# Altium schematic sheet SSS Daughter Board 5v Power.SchDoc("SSS Daughter Board 5v Power")
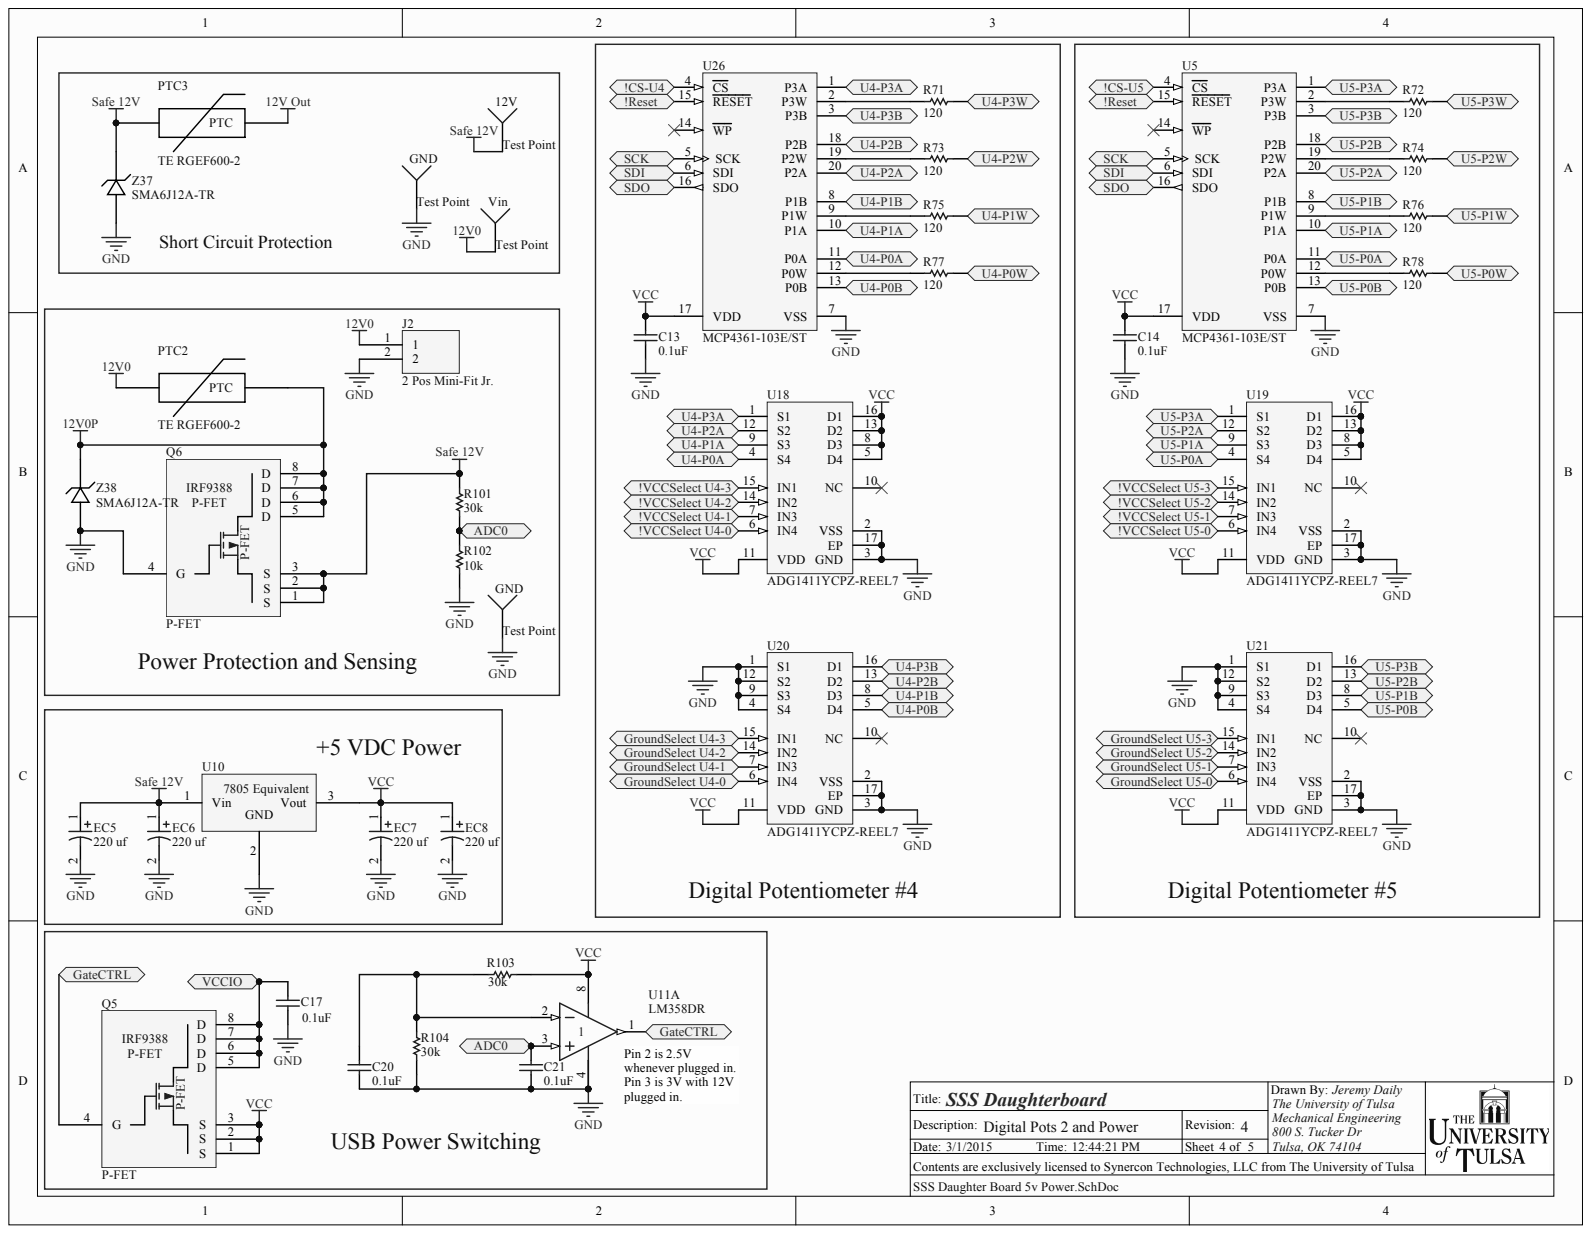
[diagram p0: SSS Daughter Board 5v Power.SchDoc]

## Parts
12V  Test Point  [PIN1]
C13  0.1uF  [0603]
C14  0.1uF  [0603]
C17  0.1uF  [0603]
C20  0.1uF  [0603]
C21  0.1uF  [0603]
EC5  220 uf  [Elec. Cap - D]
EC6  220 uf  [Elec. Cap - D]
EC7  220 uf  [Elec. Cap - D]
EC8  220 uf  [Elec. Cap - D]
GND  Test Point  [PIN1]
GND  Test Point  [PIN1]
J2  2 Pos Mini-Fit Jr.  [2 pos Mini-Fit Jr. R/A Header]
PTC2  TE RGEF600-2  [PTC]
PTC3  TE RGEF600-2  [PTC]
Q5  P-FET  [SOIC-8]
Q6  P-FET  [SOIC-8]
R71  120  [0805]
R72  120  [0805]
R73  120  [0805]
R74  120  [0805]
R75  120  [0805]
R76  120  [0805]
R77  120  [0805]
R78  120  [0805]
R101  30k  [0805]
R102  10k  [0805]
R103  30k  [0805]
R104  30k  [0805]
U5  MCP4361-103E/ST  [TSSOP-ST20_M]
U10  7805 Equivalent  [OKI-78SR]
U11  LM358DR  [SOIC-8]  (part A)
U18  ADG1411YCPZ-REEL7  [ADI-CP-16-13_M]
U19  ADG1411YCPZ-REEL7  [ADI-CP-16-13_M]
U20  ADG1411YCPZ-REEL7  [ADI-CP-16-13_M]
U21  ADG1411YCPZ-REEL7  [ADI-CP-16-13_M]
U26  MCP4361-103E/ST  [TSSOP-ST20_M]
Vin  Test Point  [PIN1]
Z37  SMA6J12A-TR  [SMA with A and K]
Z38  SMA6J12A-TR  [SMA with A and K]

## Ports
!CS-U4, !CS-U5, !RESET, !VCCSELECT U4-0, !VCCSELECT U4-1, !VCCSELECT U4-2, !VCCSELECT U4-3, !VCCSELECT U5-0, !VCCSELECT U5-1, !VCCSELECT U5-2, !VCCSELECT U5-3, ADC0, GATECTRL, GROUNDSELECT U4-0, GROUNDSELECT U4-1, GROUNDSELECT U4-2, GROUNDSELECT U4-3, GROUNDSELECT U5-0, GROUNDSELECT U5-1, GROUNDSELECT U5-2, GROUNDSELECT U5-3, SCK, SDI, SDO, U4-P0A, U4-P0B, U4-P0W, U4-P1A, U4-P1B, U4-P1W, U4-P2A, U4-P2B, U4-P2W, U4-P3A, U4-P3B, U4-P3W, U5-P0A, U5-P0B, U5-P0W, U5-P1A, U5-P1B, U5-P1W, U5-P2A, U5-P2B, U5-P2W, U5-P3A, U5-P3B, U5-P3W, VCCIO

## Nets
12V0: J2.1 PTC2.1 Vin.1
12V0P: PTC2.2 Q6.5(D) Q6.6(D) Q6.7(D) Q6.8(D) Z38.2
12V OUT: PTC3.2
GND: C13.2 C14.2 C17.2 C20.2 C21.2 EC5.2 EC6.2 EC7.2 EC8.2 GND.1 J2.2 Q6.4(G) R102.1 R104.2 U5.7(VSS) U10.2 U11.4 U18.2(VSS) U18.3(GND) U18.17(EP) U19.2(VSS) U19.3(GND) U19.17(EP) U20.1(S1) U20.2(VSS) U20.3(GND) U20.4(S4) U20.9(S3) U20.12(S2) U20.17(EP) U21.1(S1) U21.2(VSS) U21.3(GND) U21.4(S4) U21.9(S3) U21.12(S2) U21.17(EP) U26.7(VSS) Z37.1 Z38.1
SAFE 12V: 12V.1 EC5.1 EC6.1 PTC3.1 Q6.1(S) Q6.2(S) Q6.3(S) R101.2 U10.1 Z37.2
VCC: C13.1 C14.1 EC7.1 EC8.1 Q5.1(S) Q5.2(S) Q5.3(S) R103.1 U5.17(VDD) U10.3 U11.8 U18.5(D4) U18.8(D3) U18.11(VDD) U18.13(D2) U18.16(D1) U19.5(D4) U19.8(D3) U19.11(VDD) U19.13(D2) U19.16(D1) U20.11(VDD) U21.11(VDD) U26.17(VDD)
!CS-U4: U26.4(CS) ; port !CS-U4
!CS-U5: U5.4(CS) ; port !CS-U5
ADC0: C21.1 R101.1 R102.2 U11.3 ; port ADC0
SCK: U5.5(SCK) U26.5(SCK) ; port SCK
SDI: U5.6(SDI) U26.6(SDI) ; port SDI
SDO: U5.16(SDO) U26.16(SDO) ; port SDO
U4-P0A: U18.4(S4) U26.11(P0A) ; port U4-P0A
U4-P0B: U20.5(D4) U26.13(P0B) ; port U4-P0B
U4-P0W: R77.1 ; port U4-P0W
U4-P1A: U18.9(S3) U26.10(P1A) ; port U4-P1A
U4-P1B: U20.8(D3) U26.8(P1B) ; port U4-P1B
U4-P1W: R75.1 ; port U4-P1W
U4-P2A: U18.12(S2) U26.20(P2A) ; port U4-P2A
U4-P2B: U20.13(D2) U26.18(P2B) ; port U4-P2B
U4-P2W: R73.1 ; port U4-P2W
U4-P3A: U18.1(S1) U26.1(P3A) ; port U4-P3A
U4-P3B: U20.16(D1) U26.3(P3B) ; port U4-P3B
U4-P3W: R71.1 ; port U4-P3W
U5-P0A: U5.11(P0A) U19.4(S4) ; port U5-P0A
U5-P0B: U5.13(P0B) U21.5(D4) ; port U5-P0B
U5-P0W: R78.1 ; port U5-P0W
U5-P1A: U5.10(P1A) U19.9(S3) ; port U5-P1A
U5-P1B: U5.8(P1B) U21.8(D3) ; port U5-P1B
U5-P1W: R76.1 ; port U5-P1W
U5-P2A: U5.20(P2A) U19.12(S2) ; port U5-P2A
U5-P2B: U5.18(P2B) U21.13(D2) ; port U5-P2B
U5-P2W: R74.1 ; port U5-P2W
U5-P3A: U5.1(P3A) U19.1(S1) ; port U5-P3A
U5-P3B: U5.3(P3B) U21.16(D1) ; port U5-P3B
U5-P3W: R72.1 ; port U5-P3W
VCCIO: C17.1 Q5.5(D) Q5.6(D) Q5.7(D) Q5.8(D) ; port VCCIO
NetC20_1: C20.1 R103.2 R104.1 U11.2
NetQ5_4: Q5.4(G) U11.1 ; port GATECTRL
NetR71_2: R71.2 U26.2(P3W)
NetR72_2: R72.2 U5.2(P3W)
NetR73_2: R73.2 U26.19(P2W)
NetR74_2: R74.2 U5.19(P2W)
NetR75_2: R75.2 U26.9(P1W)
NetR76_2: R76.2 U5.9(P1W)
NetR77_2: R77.2 U26.12(P0W)
NetR78_2: R78.2 U5.12(P0W)
NetU5_15: U5.15(RESET) U26.15(RESET) ; port !RESET
NetU18_6: U18.6(IN4) ; port !VCCSELECT U4-0
NetU18_7: U18.7(IN3) ; port !VCCSELECT U4-1
NetU18_14: U18.14(IN2) ; port !VCCSELECT U4-2
NetU18_15: U18.15(IN1) ; port !VCCSELECT U4-3
NetU19_6: U19.6(IN4) ; port !VCCSELECT U5-0
NetU19_7: U19.7(IN3) ; port !VCCSELECT U5-1
NetU19_14: U19.14(IN2) ; port !VCCSELECT U5-2
NetU19_15: U19.15(IN1) ; port !VCCSELECT U5-3
NetU20_6: U20.6(IN4) ; port GROUNDSELECT U4-0
NetU20_7: U20.7(IN3) ; port GROUNDSELECT U4-1
NetU20_14: U20.14(IN2) ; port GROUNDSELECT U4-2
NetU20_15: U20.15(IN1) ; port GROUNDSELECT U4-3
NetU21_6: U21.6(IN4) ; port GROUNDSELECT U5-0
NetU21_7: U21.7(IN3) ; port GROUNDSELECT U5-1
NetU21_14: U21.14(IN2) ; port GROUNDSELECT U5-2
NetU21_15: U21.15(IN1) ; port GROUNDSELECT U5-3

Not connected: U5 14(WP); U18 10(NC); U19 10(NC); U20 10(NC); U21 10(NC); U26 14(WP)

## Library data of the parts
12V GND GND Vin = Socket: Component Kind: Standard; Library Name: Miscellaneous Connectors.IntLib; Signal Integrity: Connector
  12V GND GND: Value: Test Point
C13 C14 C17 C20 C21 = Cap: Component Kind: Standard; Signal Integrity: Cap; Simulation: CAP; Value: 0.1uF; Library Name: Daily_Integrated_Library.IntLib
EC5-EC8 = SMD Elec: Component Kind: Standard; Library Name: Capacitors.SchLib
J2 = 2 Pos Header: Component Kind: Standard; Library Name: Mini Fit Jr.SchLib
PTC2 PTC3 = PTC: POLYSWITCH RGE SERIES 6.0A HOLD; Manufacturer: TE Connectivity; Value: 6 Amp; Component Kind: Standard; Library Name: SSS Schematic Library.SchLib; PartNumber: RGEF600; Supplier 1: Digi-Key; Supplier Part Number 1: RGEF600HF-ND
Q5 Q6 = IRF9388: Component Kind: Standard; Library Name: Transistors.SchLib
R71-R78 = Chip Resistor: Manufacturer: Rohm Semiconductor; PartNumber: ESR10EZPF1200; Component Kind: Standard; Library Name: Daily_Integrated_Library.IntLib
R101-R104 = Chip Resistor: Component Kind: Standard; Library Name: KongsLib.SchLib
U5 U26 = MCP4361-103E/ST: Manufacturer: Microchip; Component Kind: Standard; PartNumber: MCP4361-103E/ST; Supplier 1: Digi-Key; Supplier Part Number 1: MCP4361-103E/ST-ND; Library Name: Microchip Mixed Signal.IntLib
U10 = 7805: Component Kind: Standard; Library Name: SSS Schematic Library.SchLib; Supplier 1: Digi-Key; Supplier Part Number 1: 811-2196-5-ND
U18-U21 = ADG1411YCPZ-REEL7: Manufacturer: Analog Devices; Component Kind: Standard; Library Name: Analog Devices Switches and Multiplexers Analog Switches.IntLib; PartNumber: ADG1411YCPZ-REEL7; Supplier 1: Digi-Key; Supplier Part Number 1: ADG1411YCPZ-REEL7TR-ND
Z37 Z38 = Zener: Component Kind: Standard; Library Name: SSS Schematic Library.SchLib
U11 = LM358DR: Component Kind: Standard; Library Name: TI Operational Amplifier.IntLib; PCB3D: D008
